# Altium schematic sheet Current_Source.SchDoc(Current_Source)
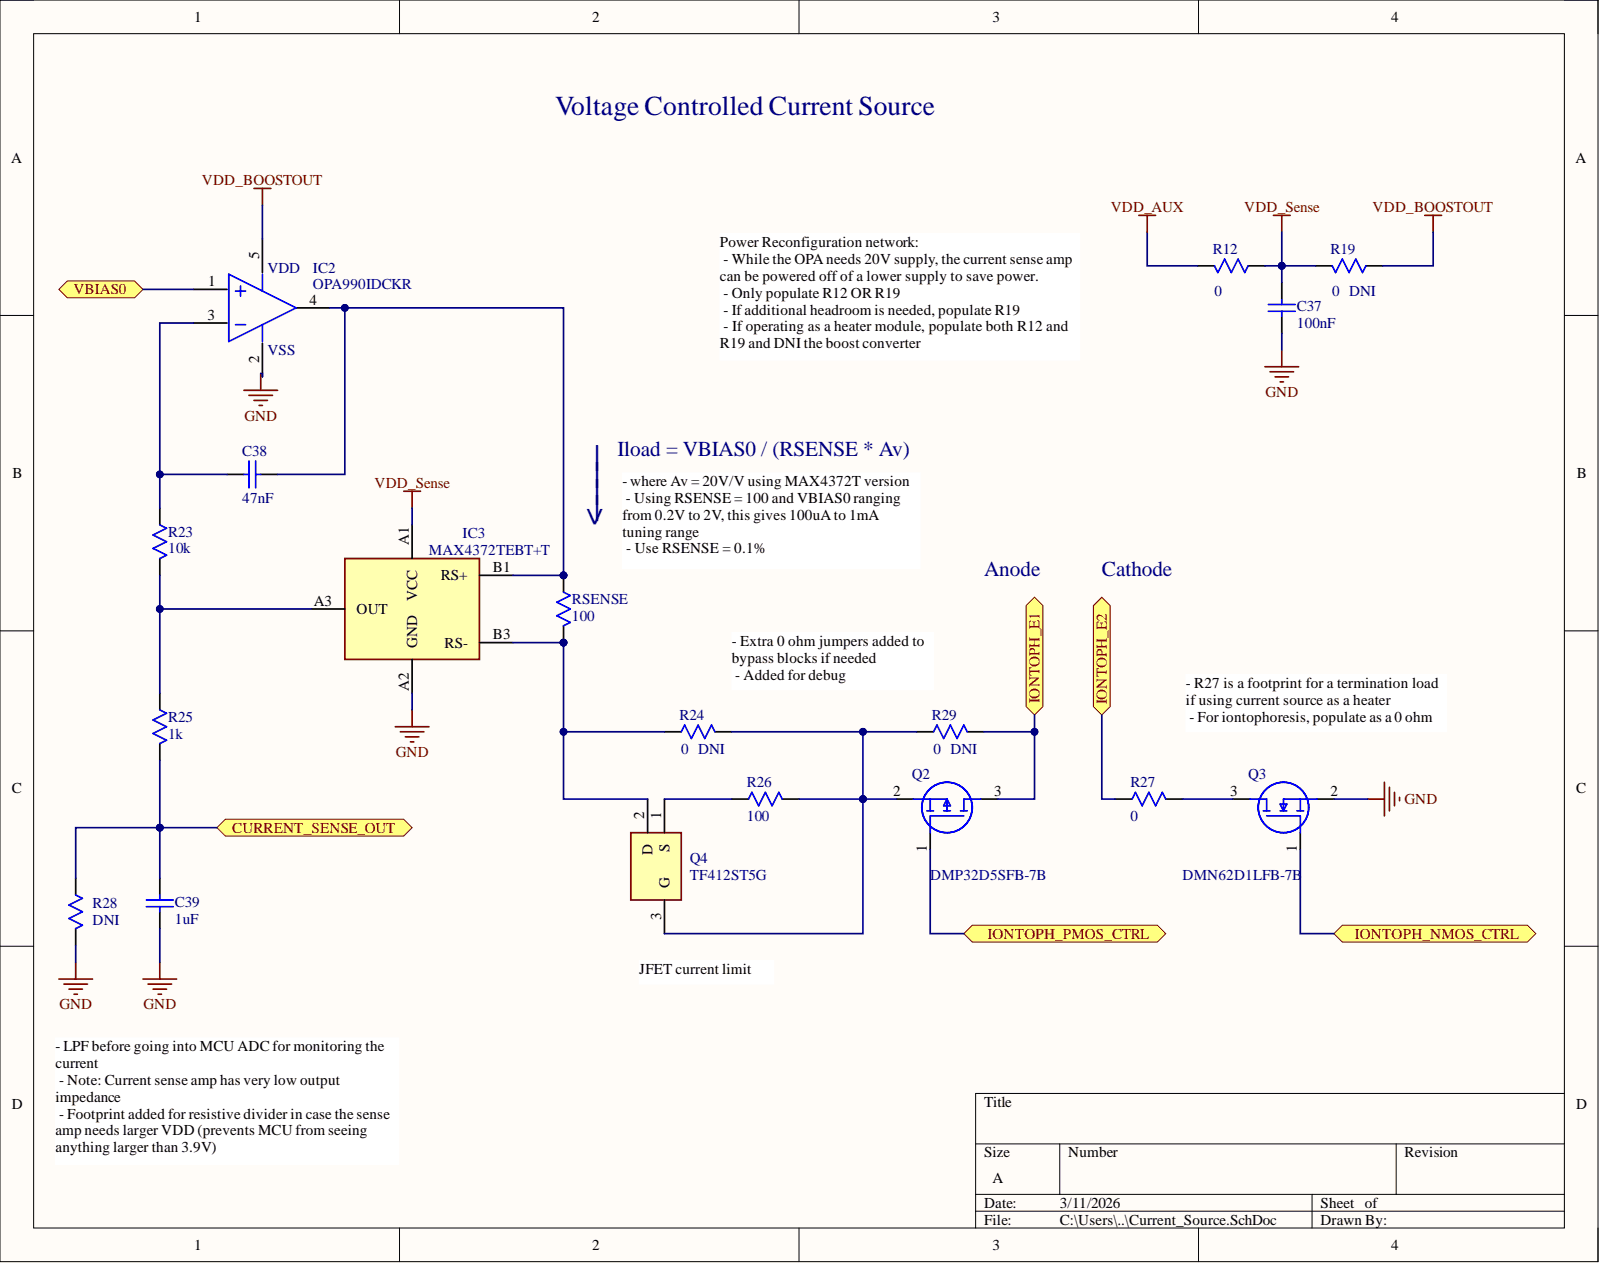
[diagram p0: Current_Source.SchDoc]

## Parts
C37  value 100nF  [0201 cap]  (hidden comment: CAP)
C38  value 47nF  [0201 cap]  (hidden comment: CAP)
C39  value 1uF  [0201 cap]  (hidden comment: CAP)
IC2  OPA990IDCKR  [SOT65P210X110-5N]
IC3  MAX4372TEBT+T  [BGA5C50P3X2_152X100X67]
Q2  DMP32D5SFB-7B  [DESD6V8DLP7]
Q3  DMN62D1LFB-7B  [DESD6V8DLP7]
Q4  TF412ST5G  [TF412ST5G]
R12  value 0  [0201 res]  (hidden comment: RES)
R19  value 0  Do Not Install: DNI  [0201 res]  (hidden comment: RES)
R23  value 10k  [0201 res]  (hidden comment: RES)
R24  value 0  Do Not Install: DNI  [0201 res]  (hidden comment: RES)
R25  value 1k  [0201 res]  (hidden comment: RES)
R26  value 100  [0402-RES]  (hidden comment: RES)
R27  value 0  [0201 res]  (hidden comment: RES)
R28  Do Not Install: DNI  [0201 res]  (hidden comment: RES)
R29  value 0  Do Not Install: DNI  [0201 res]  (hidden comment: RES)
RSENSE  value 100  [0402-RES]  (hidden comment: RES)

## Ports
CURRENT_SENSE_OUT, IONTOPH_E1, IONTOPH_E2, IONTOPH_NMOS_CTRL, IONTOPH_PMOS_CTRL, VBIAS0

## Nets
GND: C37.1 C39.1 IC2.2 IC3.A2(GND) Q3.2 R28.2
VDD_AUX: R12.2
VDD_BOOSTOUT: IC2.5 R19.1
VDD_Sense: C37.2 IC3.A1(VCC) R12.1 R19.2
CURRENT_SENSE_OUT: C39.2 R25.2 R28.1 ; port CURRENT_SENSE_OUT
IONTOPH_E1: Q2.3 R29.1 ; port IONTOPH_E1
IONTOPH_E2: R27.1 ; port IONTOPH_E2
IONTOPH_NMOS_CTRL: Q3.1 ; port IONTOPH_NMOS_CTRL
IONTOPH_PMOS_CTRL: Q2.1 ; port IONTOPH_PMOS_CTRL
VBIAS0: IC2.1 ; port VBIAS0
NetC38_1: C38.1 IC2.3 R23.1
NetC38_2: C38.2 IC2.4 IC3.B1(RS+) RSENSE.1
NetIC3_A3: IC3.A3(OUT) R23.2 R25.1
NetIC3_B3: IC3.B3(RS-) Q4.2 R24.2 RSENSE.2
NetQ2_2: Q2.2 Q4.3 R24.1 R26.2 R29.2
NetQ3_3: Q3.3 R27.2
NetQ4_1: Q4.1 R26.1

## Library data of the parts
C37-C39 = CAP SMD: Multilayer Chip Capacitor; Designer: OMIGA; Component Kind: Standard; Library Name: Schlib.SchLib; Signal Integrity: CAP; Simulation: MLCC
IC2 = OPA990IDCKR: Integrated Circuit; Manufacturer_Name: Texas Instruments; Arrow Part Number: OPA990IDCKR; Arrow Price/Stock: https://www.arrow.com/en/products/opa990idckr/texas-instruments?region=nac; Component Kind: Standard; Datasheet Link: https://www.ti.com/lit/gpn/OPA990; Height: 1.1mm; Library Name: Schlib.SchLib; Manufacturer_Part_Number: OPA990IDCKR; Mouser Part Number: 595-OPA990IDCKR; Mouser Price/Stock: https://www.mouser.co.uk/ProductDetail/Texas-Instruments/OPA990IDCKR?qs=XeJtXLiO41TSF5QBGuARgQ%3D%3D
IC3 = MAX4372TEBT+T: Integrated Circuit; Manufacturer_Name: Analog Devices; Component Kind: Standard; Datasheet Link: https://pdfserv.maximintegrated.com/en/ds/MAX4372-MAX4372T.pdf; Height: 0.67mm; Library Name: Schlib.SchLib; Manufacturer_Part_Number: MAX4372TEBT+T; Mouser Part Number: 700-MAX4372TEBT+T; Mouser Price/Stock: https://www.mouser.co.uk/ProductDetail/Maxim-Integrated/MAX4372TEBT%2bT?qs=1eQvB6Dk1vi20izC9qMksw%3D%3D
Q2 = DMP32D5SFB-7B: MOSFET (P-Channel); Manufacturer_Name: Diodes Inc.; Arrow Part Number: DMP32D5SFB-7B; Arrow Price/Stock: https://www.arrow.com/en/products/dmp32d5sfb-7b/diodes-incorporated; Component Kind: Standard; Datasheet Link: https://www.diodes.com/assets/Datasheets/DMP32D5SFB.pdf; Height: 0.53mm; Library Name: Schlib.SchLib; Manufacturer_Part_Number: DMP32D5SFB-7B; Mouser Part Number: 621-DMP32D5SFB-7B; Mouser Price/Stock: https://www.mouser.co.uk/ProductDetail/Diodes-Incorporated/DMP32D5SFB-7B?qs=MNIFWdyJgboORiafCqUWHQ%3D%3D
Q3 = DMN62D1LFB-7B: MOSFET (N-Channel); Manufacturer_Name: Diodes Inc.; Arrow Part Number: DMN62D1LFB-7B; Arrow Price/Stock: https://www.arrow.com/en/products/dmn62d1lfb-7b/diodes-incorporated?region=nac; Component Kind: Standard; Datasheet Link: https://www.diodes.com/assets/Datasheets/DMN62D1LFB.pdf; Height: 0.53mm; Manufacturer_Part_Number: DMN62D1LFB-7B; Mouser Part Number: 621-DMN62D1LFB-7B; Mouser Price/Stock: https://www.mouser.co.uk/ProductDetail/Diodes-Incorporated/DMN62D1LFB-7B?qs=y6ZabgHbY%252BxTi2UB8%252BIvCg%3D%3D
Q4 = TF412ST5G: N-ch JFET Transistor; Manufacturer_Name: onsemi; Arrow Part Number: TF412ST5G; Arrow Price/Stock: https://www.arrow.com/en/products/tf412st5g/on-semiconductor; Component Kind: Standard; Datasheet Link: https://datasheet.datasheetarchive.com/originals/distributors/DKDS-17/338694.pdf; Height: 0.44mm; Library Name: Schlib.SchLib; Manufacturer_Part_Number: TF412ST5G; Mouser Part Number: 863-TF412ST5G; Mouser Price/Stock: https://www.mouser.co.uk/ProductDetail/onsemi/TF412ST5G?qs=k6dkFvHqcvBP99I1DFAJcQ%3D%3D
R12 R19 R23-R29 RSENSE = RES SMD: Surface Mount Resistor; Designer: OMIGA; Component Kind: Standard; Library Name: Schlib.SchLib; Signal Integrity: RES; Simulation: RES SMD
